# Altium schematic sheet 02 FPGA_CFG.SchDoc
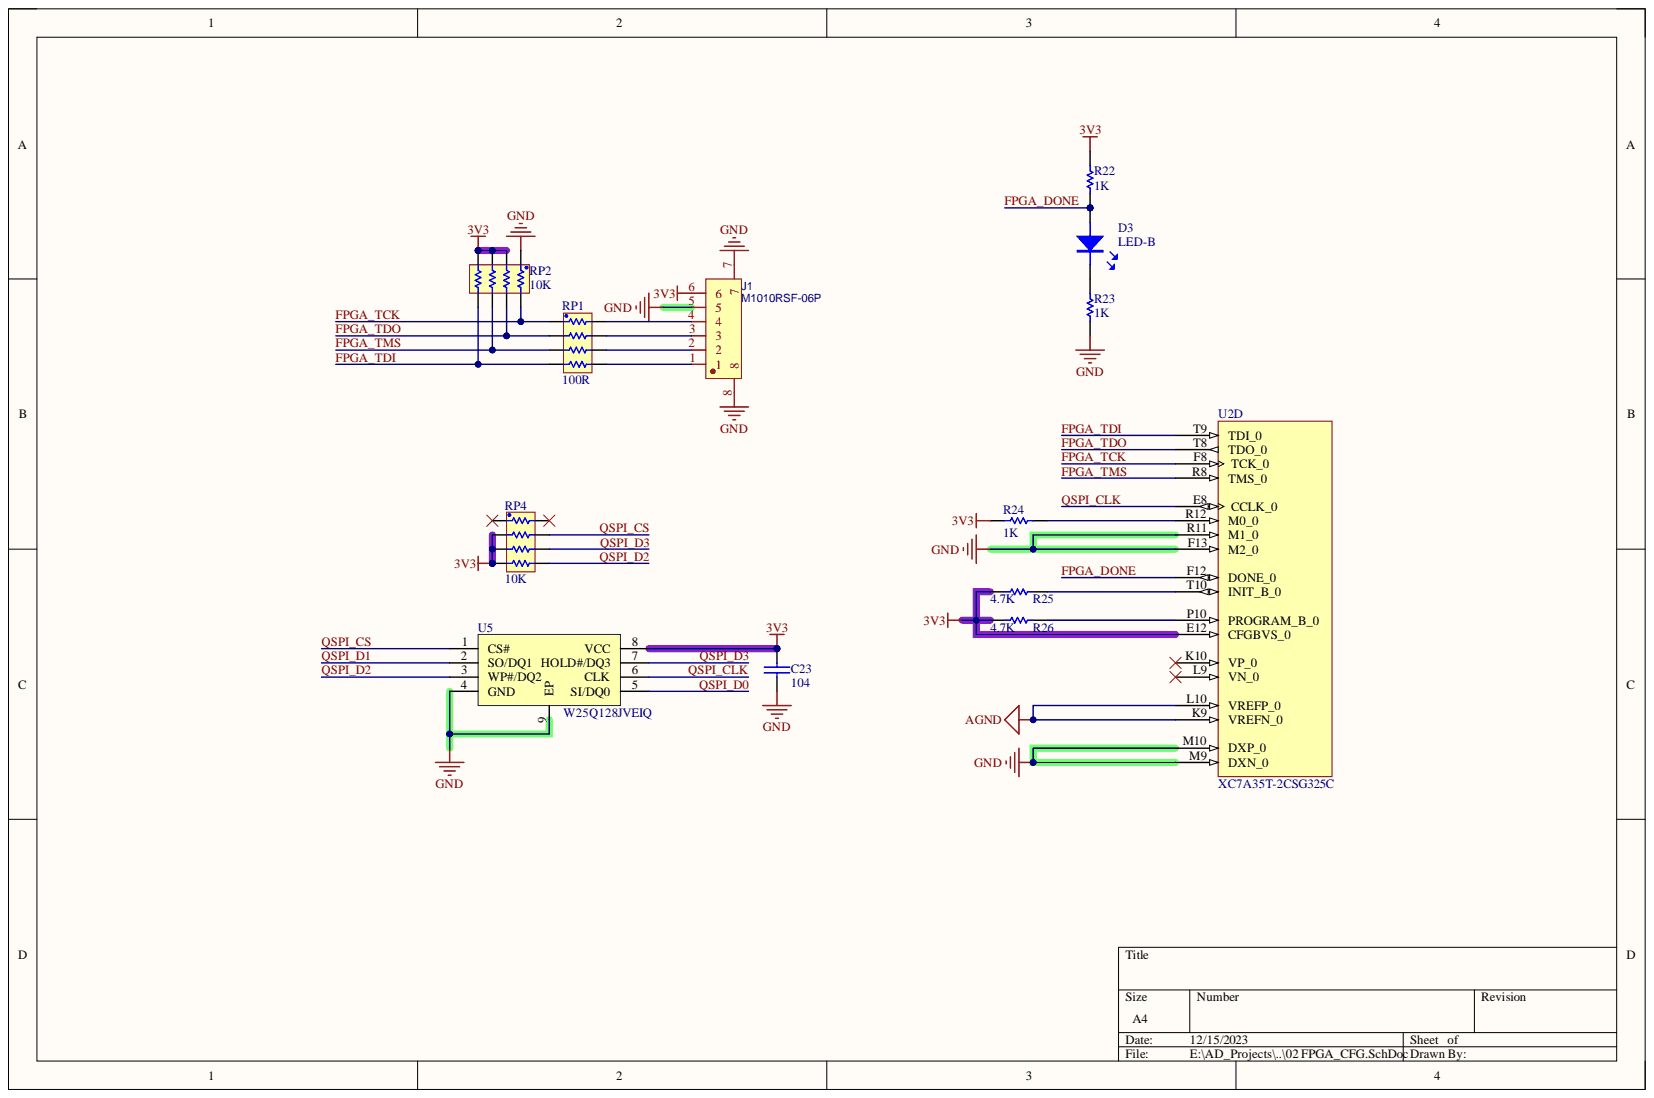
[diagram p0: 02 FPGA_CFG.SchDoc]

## Parts
C23  104  [0402C]
D3  LED-B  [LED-0603B]
J1  M1010RSF-06P  [CONN-SMD_6P-P1.00_XFCN_M1010RSF-06P]
R22  1K  [0402R]
R23  1K  [0402R]
R24  1K  [0402R]
R25  4.7K  [0402R]
R26  4.7K  [0402R]
RP1  100R  [贴片排阻]
RP2  10K  [贴片排阻]
RP4  10K  [贴片排阻]
U2  XC7A35T-2CSG325C  [CSG325]  (part D)
U5  W25Q128JVEIQ  [WSON-8_L6.0-W8.0-P1.27-BL-EP]

## Nets
3V3: C23.2 J1.6 R22.2 R24.1 R25.1 R26.1 RP2.2 RP2.3 RP2.4 RP4.2 RP4.3 RP4.4 U2.E12(CFGBVS_0) U5.8(VCC)
AGND: U2.K9(VREFN_0) U2.L10(VREFP_0)
GND: C23.1 J1.5 J1.7 J1.8 R23.1 RP2.1 U2.F13(M2_0) U2.M9(DXN_0) U2.M10(DXP_0) U2.R11(M1_0) U5.4(GND) U5.9(EP)
FPGA_DONE: D3.A R22.1 U2.F12(DONE_0)
FPGA_TCK: RP1.1 RP2.8 U2.F8(TCK_0)
FPGA_TDI: RP1.4 RP2.5 U2.T9(TDI_0)
FPGA_TDO: RP1.2 RP2.7 U2.T8(TDO_0)
FPGA_TMS: RP1.3 RP2.6 U2.R8(TMS_0)
QSPI_CLK: U2.E8(CCLK_0) U5.6(CLK)
QSPI_CS: RP4.7 U5.1(CS#)
QSPI_D0: U5.5(SI/DQ0)
QSPI_D1: U5.2(SO/DQ1)
QSPI_D2: RP4.5 U5.3(WP#/DQ2)
QSPI_D3: RP4.6 U5.7(HOLD#/DQ3)
NetD3_K: D3.K R23.2
NetJ1_1: J1.1 RP1.5
NetJ1_2: J1.2 RP1.6
NetJ1_3: J1.3 RP1.7
NetJ1_4: J1.4 RP1.8
NetR24_2: R24.2 U2.R12(M0_0)
NetR25_2: R25.2 U2.T10(INIT_B_0)
NetR26_2: R26.2 U2.P10(PROGRAM_B_0)

Not connected: RP4 1 8; U2 K10(VP_0) L9(VN_0)

## Library data of the parts
C23 = CAP: 无极性电容; Component Kind: Standard; Library Name: 电容.IntLib
D3 = LED: Component Kind: Standard; Library Name: LED.IntLib
J1 = SH1.0_6P: Manufacturer: XFCN(兴飞); Contributor: lcsc; Component Kind: Standard; Library Name: 未分类IC.IntLib; Manufacturer Part: M1010RSF-06P; spicePre: U; spiceSymbolName: M1010RSF-06P; Supplier: LCSC; Supplier Part: C5249790
R22-R26 = RES: Component Kind: Standard; Library Name: 电阻.IntLib
RP1 RP2 RP4 = SMT_RES_PACK8: Component Kind: Standard; Library Name: 电阻.IntLib
U2 = XC7A35T-2CSG325C: Artix-7 FPGA, 150 User I/Os, 4 GTP, 324-Ball BGA, Speed Grade 2, Commercial Grade, Pb-Free; Manufacturer: Xilinx; Available User I/O: 150; Clock Mgmt Tiles (CMTs): 5; GTP: 4; Logic Cells: 33280; Speed Grades: 2; Total Block RAM: 1800 Kb; Component Kind: Standard; ComponentLink1Description: Manufacturer URL; ComponentLink1URL: http://www.xilinx.com/; ComponentLink2Description: Datasheet; ComponentLink2URL: http://www.xilinx.com/support/documentation/data_sheets/ds180_7Series_Overview.pdf; ComponentLink3Description: Package Specification; ComponentLink3URL: http://www.xilinx.com/support/documentation/user_guides/ug475_7Series_Pkg_Pinout.pdf; ComponentLink4Description: DC and Switching Characteristics; ComponentLink4URL: http://www.xilinx.com/support/documentation/data_sheets/ds181_Artix_7_Data_Sheet.pdf; ComponentLink5Description: Package File; ComponentLink5URL: http://www.xilinx.com/support/packagefiles/a7packages/xc7a200tsbg484pkg.txt; ComponentLink6Description: Packaging and Pinout; ComponentLink6URL: http://www.xilinx.com/support/documentation/user_guides/ug475_7Series_Pkg_Pinout.pdf; DatasheetVersion: v1.15; Library Name: Xilinx Artix-7.IntLib; Maximum Distributed RAM: 400 Kb; Mounting Technology: Surface Mount; NexusDeviceID: XC7A35T-2CSG325C; Operating Temperature Range: Commercial; PackageDescription: 324-Ball Wire-Bond Chip-Scale BGA, Body 15 x 15 mm, Pitch 0.8 mm; PackageReference: CSG-325; PackageVersion: Rev. 1.11; PartNumber: XC7A35T-2CSG325C
U5 = 25_SERIES_FLASH_WSON: Component Kind: Standard; Library Name: 未分类IC.IntLib
